# Altium schematic sheet Sensing.SchDoc
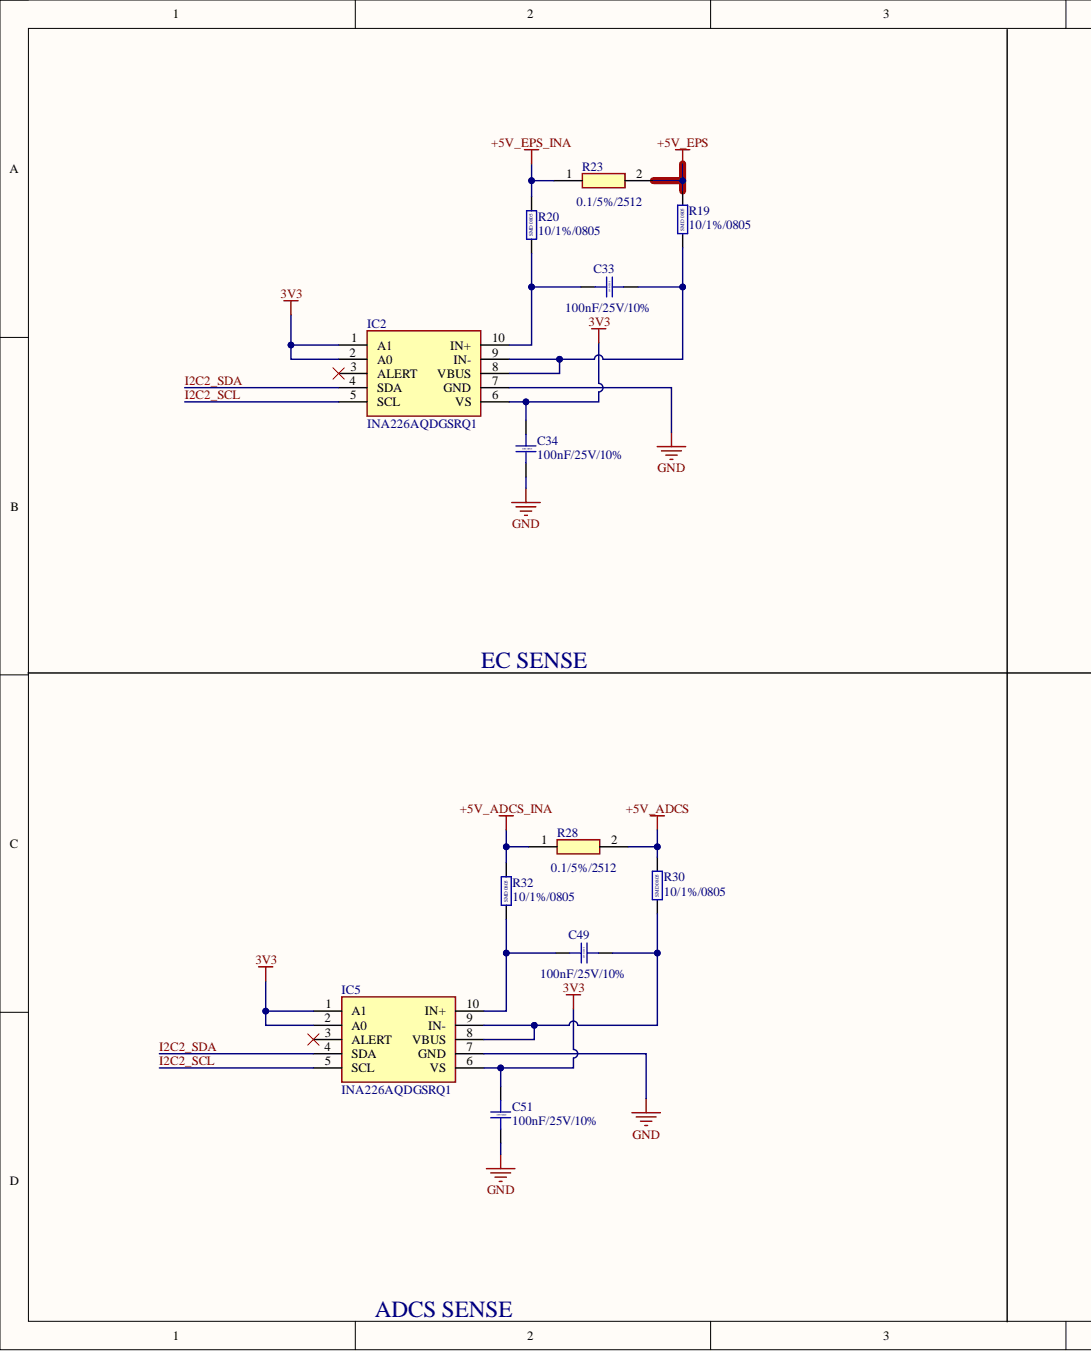
[diagram p0: Sensing.SchDoc, left]
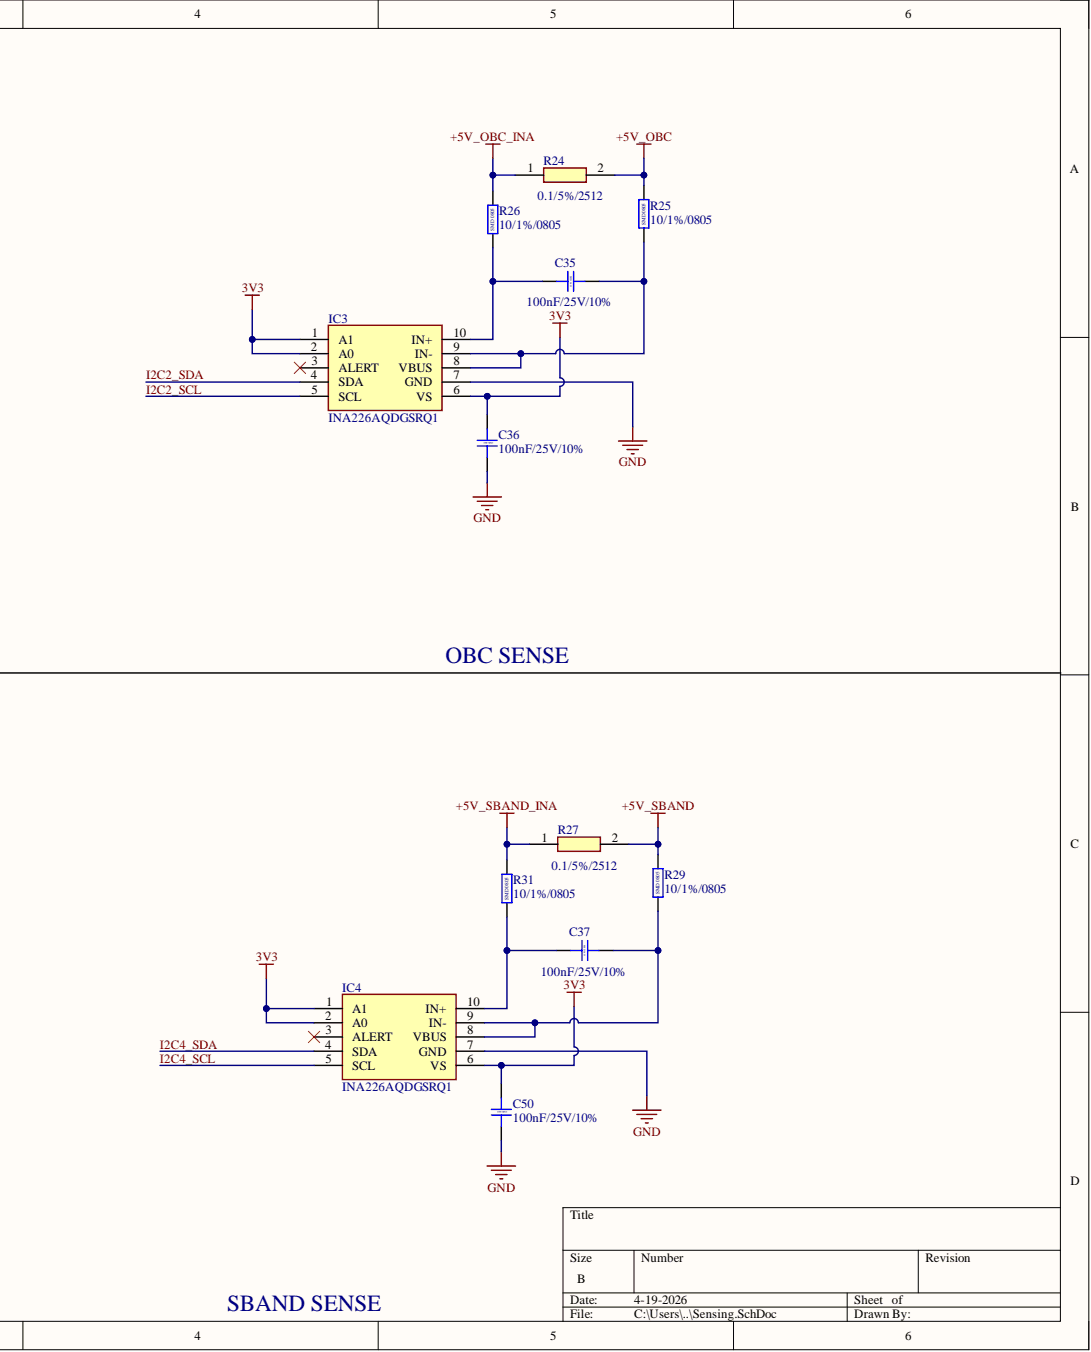
[diagram p1: Sensing.SchDoc, right]

## Parts
C33  100nF/25V/10%  [CAP_0603]
C34  100nF/25V/10%  [CAP_0603]
C35  100nF/25V/10%  [CAP_0603]
C36  100nF/25V/10%  [CAP_0603]
C37  100nF/25V/10%  [CAP_0603]
C49  100nF/25V/10%  [CAP_0603]
C50  100nF/25V/10%  [CAP_0603]
C51  100nF/25V/10%  [CAP_0603]
IC2  INA226AQDGSRQ1  [SOP50P490X110-10N]
IC3  INA226AQDGSRQ1  [SOP50P490X110-10N]
IC4  INA226AQDGSRQ1  [SOP50P490X110-10N]
IC5  INA226AQDGSRQ1  [SOP50P490X110-10N]
R19  10/1%/0805  [RES_0805]
R20  10/1%/0805  [RES_0805]
R23  0.1/5%/2512  [RESC6331X80N]
R24  0.1/5%/2512  [RESC6331X80N]
R25  10/1%/0805  [RES_0805]
R26  10/1%/0805  [RES_0805]
R27  0.1/5%/2512  [RESC6331X80N]
R28  0.1/5%/2512  [RESC6331X80N]
R29  10/1%/0805  [RES_0805]
R30  10/1%/0805  [RES_0805]
R31  10/1%/0805  [RES_0805]
R32  10/1%/0805  [RES_0805]

## Nets
3V3: C34.2 C36.2 C50.2 C51.2 IC2.1(A1) IC2.2(A0) IC2.6(VS) IC3.1(A1) IC3.2(A0) IC3.6(VS) IC4.1(A1) IC4.2(A0) IC4.6(VS) IC5.1(A1) IC5.2(A0) IC5.6(VS)
+5V_ADCS: R28.2 R30.2
+5V_ADCS_INA: R28.1 R32.2
+5V_EPS: R19.2 R23.2
+5V_EPS_INA: R20.2 R23.1
+5V_OBC: R24.2 R25.2
+5V_OBC_INA: R24.1 R26.2
+5V_SBAND: R27.2 R29.2
+5V_SBAND_INA: R27.1 R31.2
GND: C34.1 C36.1 C50.1 C51.1 IC2.7(GND) IC3.7(GND) IC4.7(GND) IC5.7(GND)
I2C2_SCL: IC2.5(SCL) IC3.5(SCL) IC5.5(SCL)
I2C2_SDA: IC2.4(SDA) IC3.4(SDA) IC5.4(SDA)
I2C4_SCL: IC4.5(SCL)
I2C4_SDA: IC4.4(SDA)
NetC33_1: C33.1 IC2.8(VBUS) IC2.9(IN-) R19.1
NetC33_2: C33.2 IC2.10(IN+) R20.1
NetC35_1: C35.1 IC3.8(VBUS) IC3.9(IN-) R25.1
NetC35_2: C35.2 IC3.10(IN+) R26.1
NetC37_1: C37.1 IC4.8(VBUS) IC4.9(IN-) R29.1
NetC37_2: C37.2 IC4.10(IN+) R31.1
NetC49_1: C49.1 IC5.8(VBUS) IC5.9(IN-) R30.1
NetC49_2: C49.2 IC5.10(IN+) R32.1

Not connected: IC2 3(ALERT); IC3 3(ALERT); IC4 3(ALERT); IC5 3(ALERT)

## Library data of the parts
C33-C37 C49-C51 = Capacitor-SMD-0603: C0603C104K3RACTU; Component Kind: Standard; Library Name: STeRG-Passives-Standard.SchLib
IC2-IC5 = INA226AQDGSRQ1: Integrated Circuit; Manufacturer_Name: Texas Instruments; Arrow Part Number: INA226AQDGSRQ1; Arrow Price/Stock: https://www.arrow.com/en/products/ina226aqdgsrq1/texas-instruments?utm_currency=USD&region=nac; Component Kind: Standard; Datasheet Link: http://www.ti.com/lit/gpn/ina226-q1; Height: 1.1mm; Library Name: SamacSys.SchLib; Manufacturer_Part_Number: INA226AQDGSRQ1; Mouser Part Number: 595-INA226AQDGSRQ1; Mouser Price/Stock: https://www.mouser.co.uk/ProductDetail/Texas-Instruments/INA226AQDGSRQ1?qs=uNh9J9Y3wTf1B1%2FBFFp7SA%3D%3D
R19 R20 R25 R26 R29-R32 = Resistor-SMD-0805: CRCW080510R0FKEA; Component Kind: Standard; Library Name: STeRG-Passives-Standard.SchLib
R23 R24 R27 R28 = CRM2512-JX-R100ELF: Resistor; Manufacturer_Name: Bourns; Arrow Part Number: CRM2512-JX-R100ELF; Arrow Price/Stock: https://www.arrow.com/en/products/crm2512-jx-r100elf/bourns; Component Kind: Standard; Datasheet Link: http://www.bourns.com/data/global/pdfs/CRM0805_1206_2010.pdf; Height: 0.8mm; Manufacturer_Part_Number: CRM2512-JX-R100ELF; Mouser Part Number: 652-CRM2512JXR100ELF; Mouser Price/Stock: https://www.mouser.co.uk/ProductDetail/Bourns/CRM2512-JX-R100ELF?qs=fxrnC0PBR6XYVKzZND0J4Q%3D%3D
